# Altium schematic sheet Sıcaklık_Nem.SchDoc(Sıcaklık_Nem)
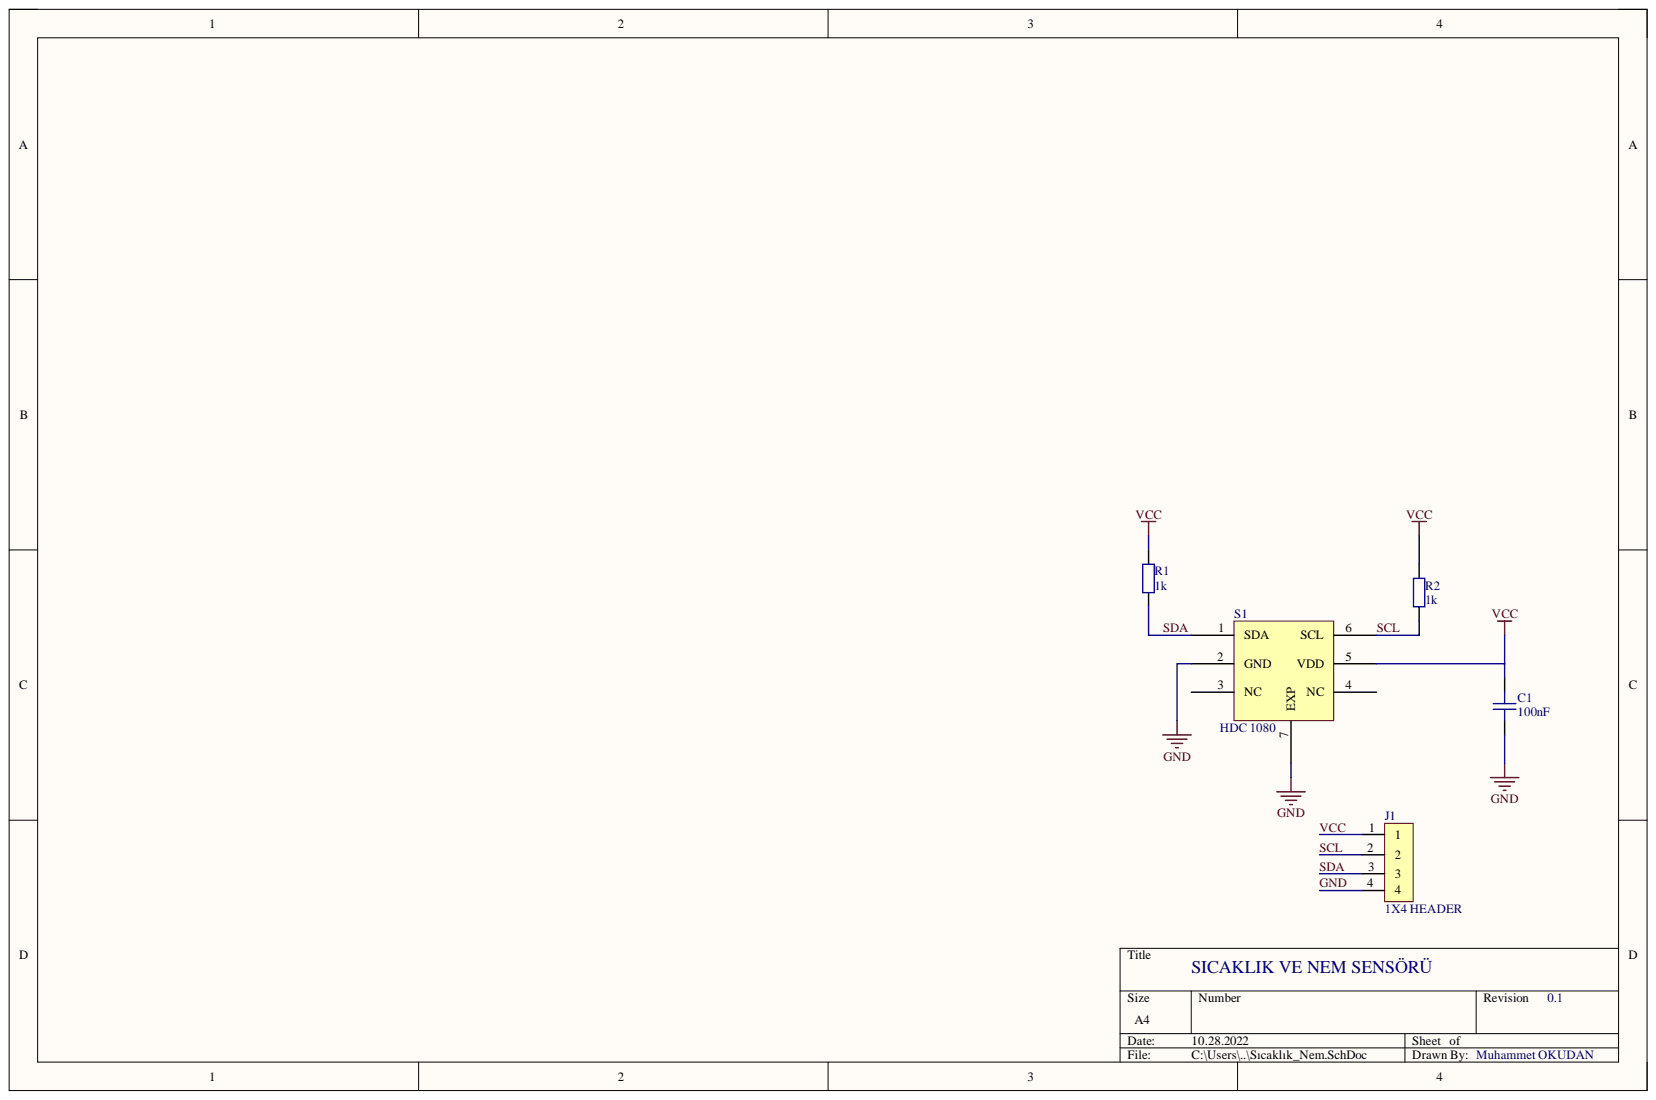
[diagram p0: Sıcaklık_Nem.SchDoc]

## Parts
C1  100nF  [0603 CAP]
J1  1X4 HEADER  [1X4 Header 2.54mm]
R1  1k  [0603 RES]
R2  1k  [0603 RES]
S1  HDC 1080  [HDC1080]

## Nets
GND: C1.1 J1.4 S1.2(GND) S1.7(EXP)
SCL: J1.2 R2.1 S1.6(SCL)
SDA: J1.3 R1.1 S1.1(SDA)
VCC: C1.2 J1.1 R1.2 R2.2 S1.5(VDD)

Not connected: S1 3(NC) 4(NC)

## Library data of the parts
C1 = 0603 cap: Component Kind: Standard; Library Name: Sıcaklık_Nem.SchLib
R1 R2 = 0603 Res: Component Kind: Standard; Library Name: Sıcaklık_Nem.SchLib
S1 = HDC 1080: HDC1080 SICAKLIK VE NEM SENSÖRÜ; Component Kind: Standard; Library Name: Sıcaklık_Nem.SchLib
J1 = 1X4 HEADER: Component Kind: Standard; Library Name: Sıcaklık_Nem.SchLib
